# Altium schematic sheet ee453_pcb_N-B-S.SchDoc(ee453_pcb_N-B-S)
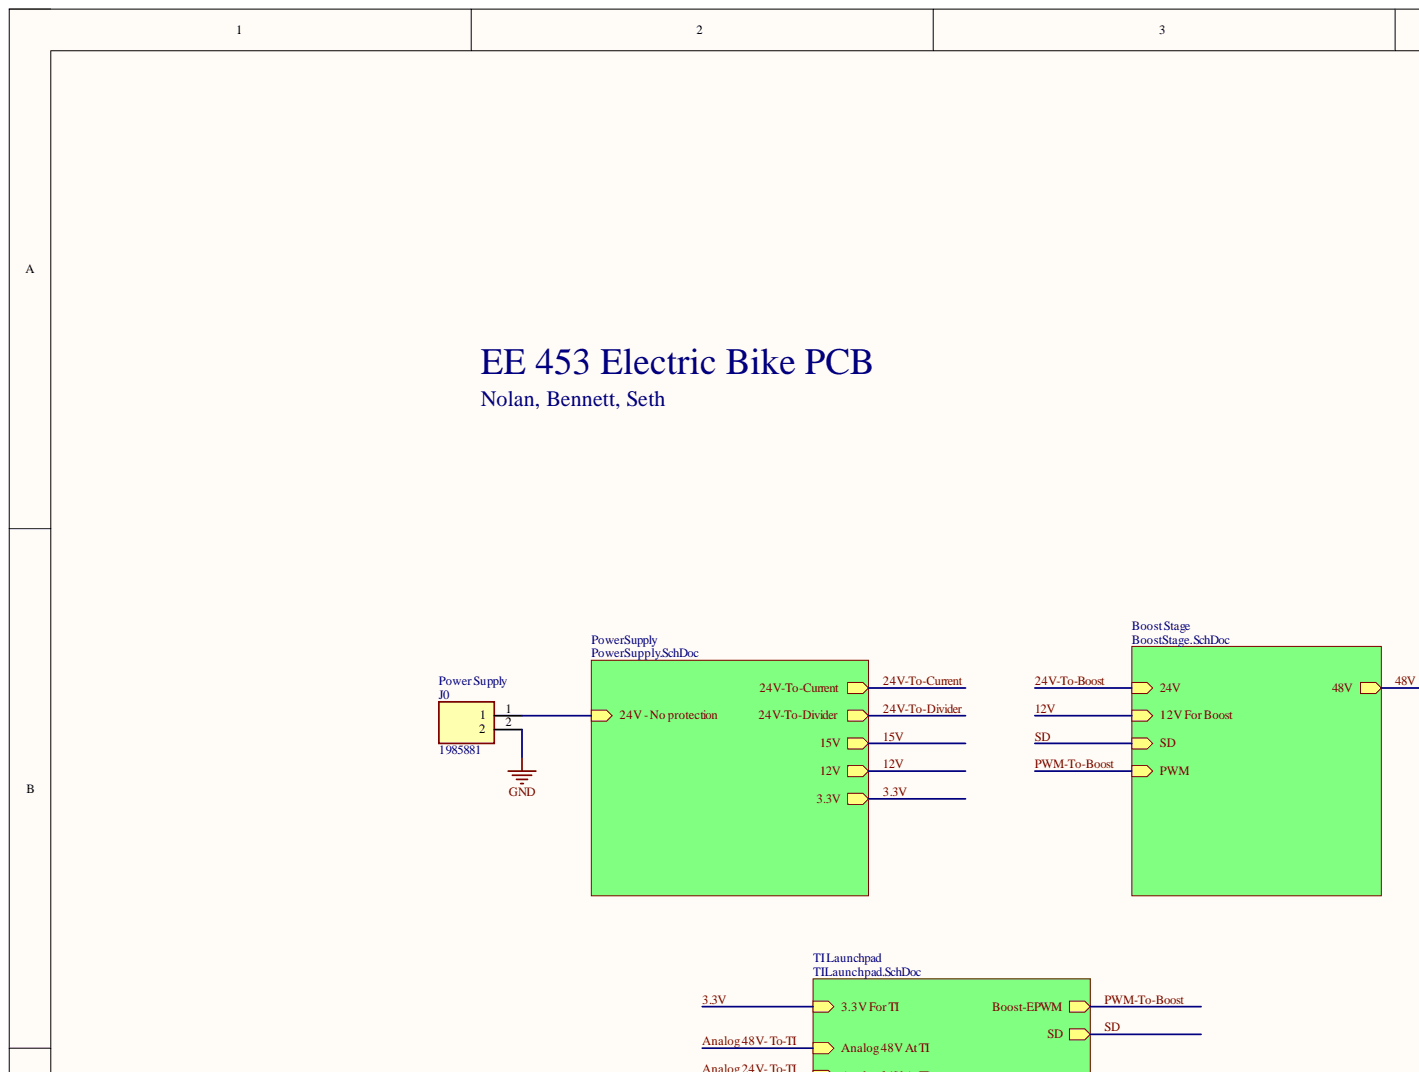
[diagram p0: ee453_pcb_N-B-S.SchDoc, top left]
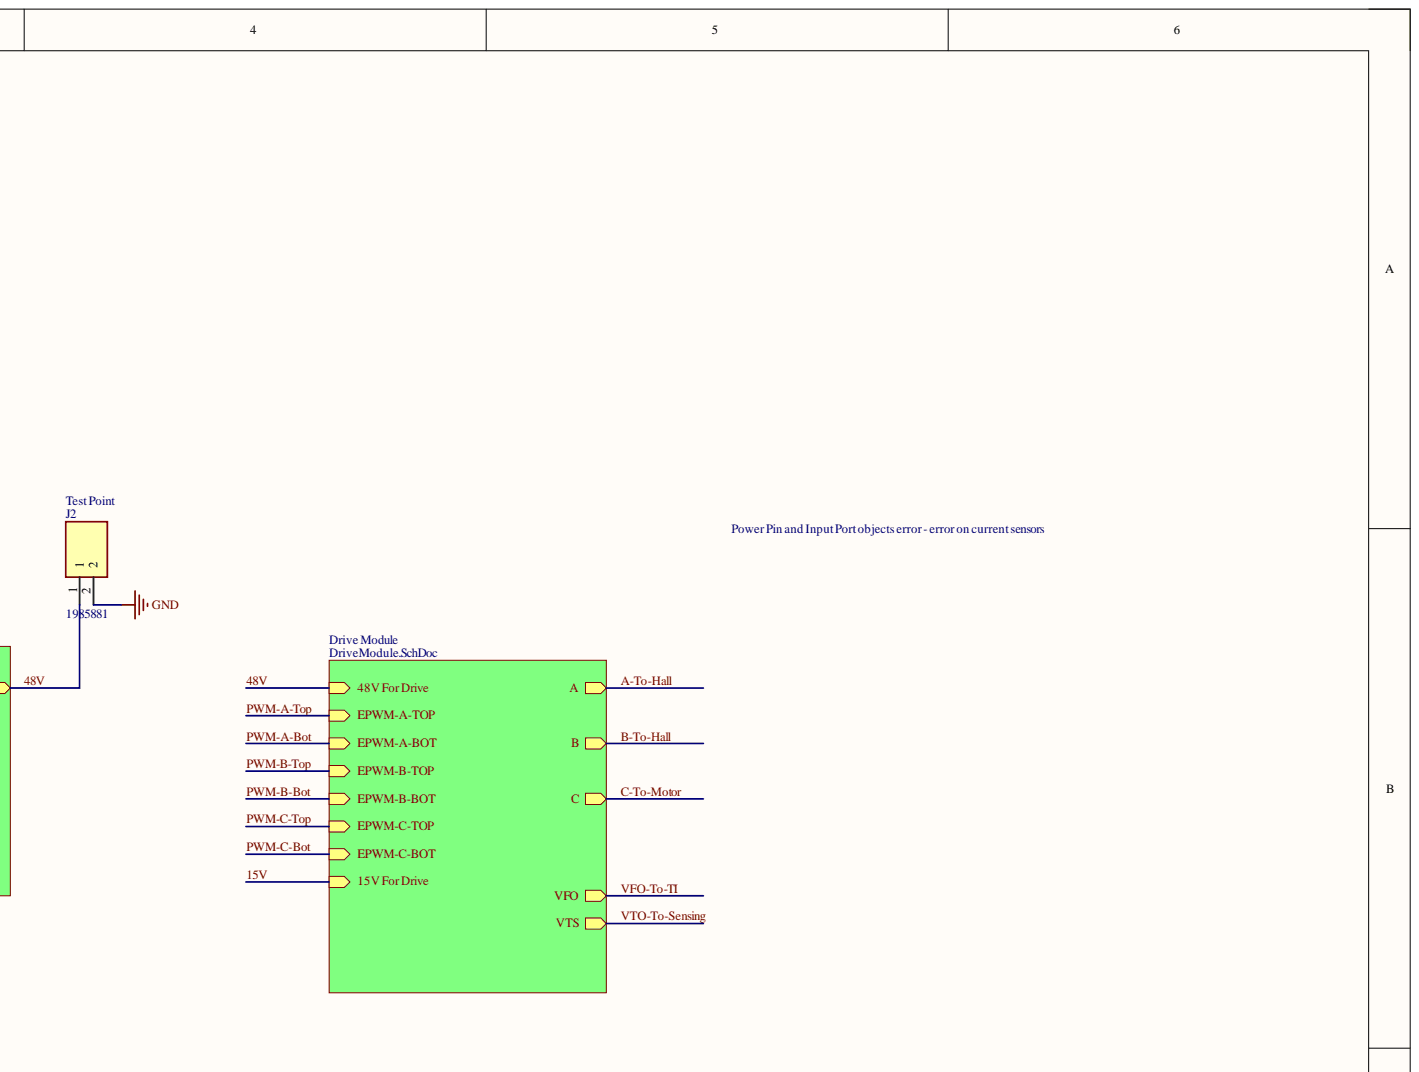
[diagram p1: ee453_pcb_N-B-S.SchDoc, top right]
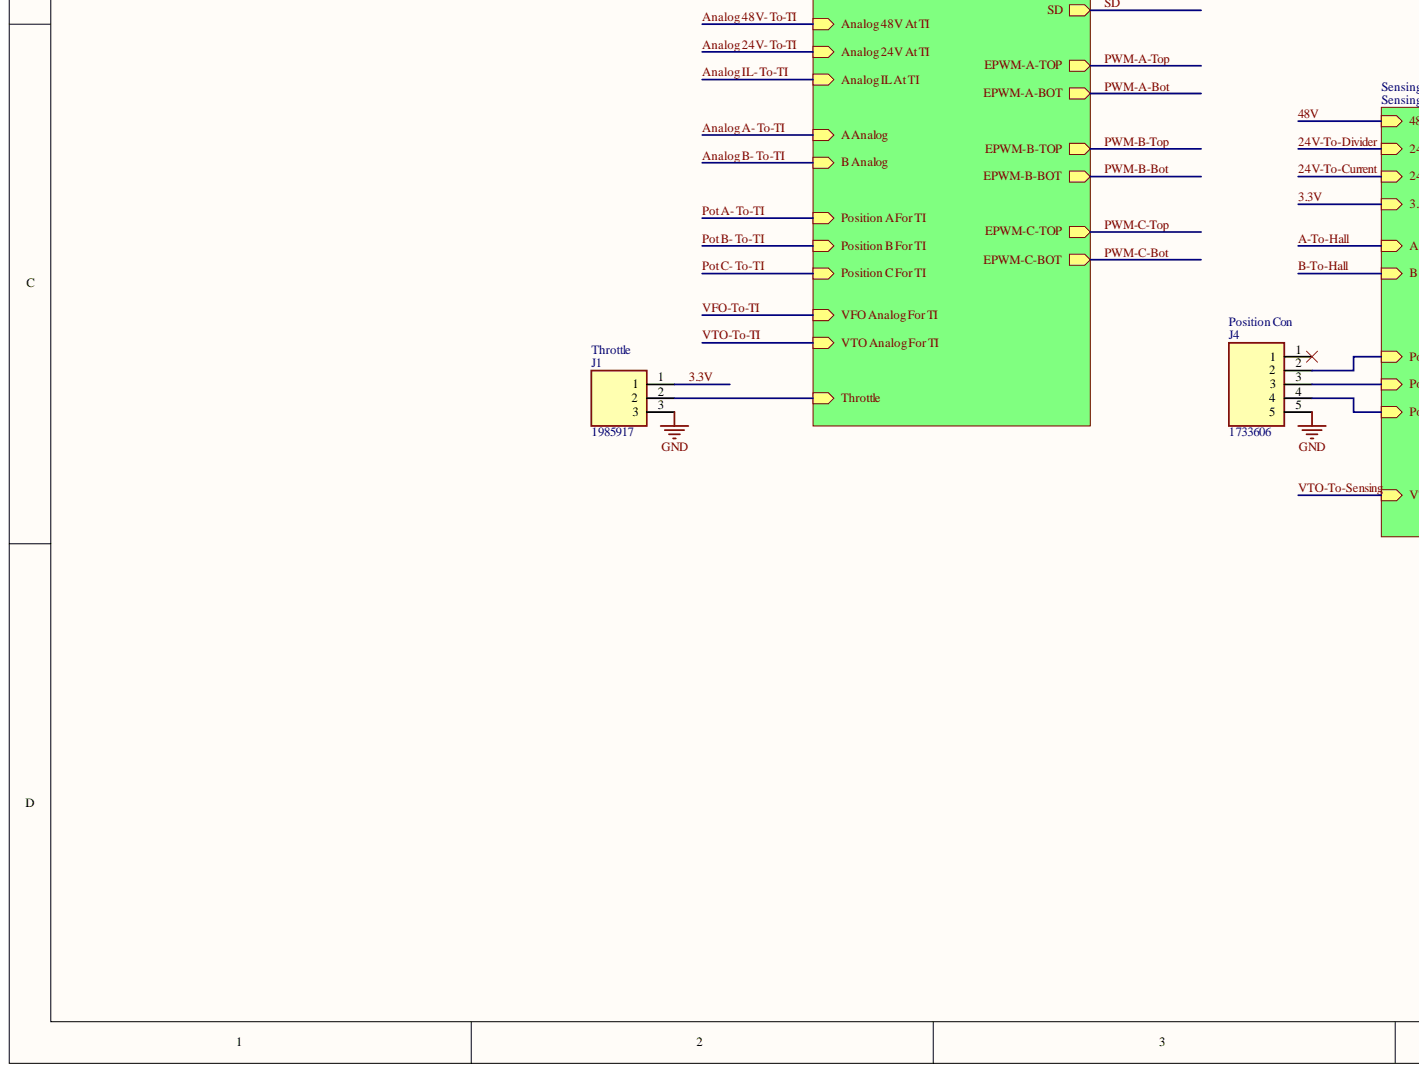
[diagram p2: ee453_pcb_N-B-S.SchDoc, bottom left]
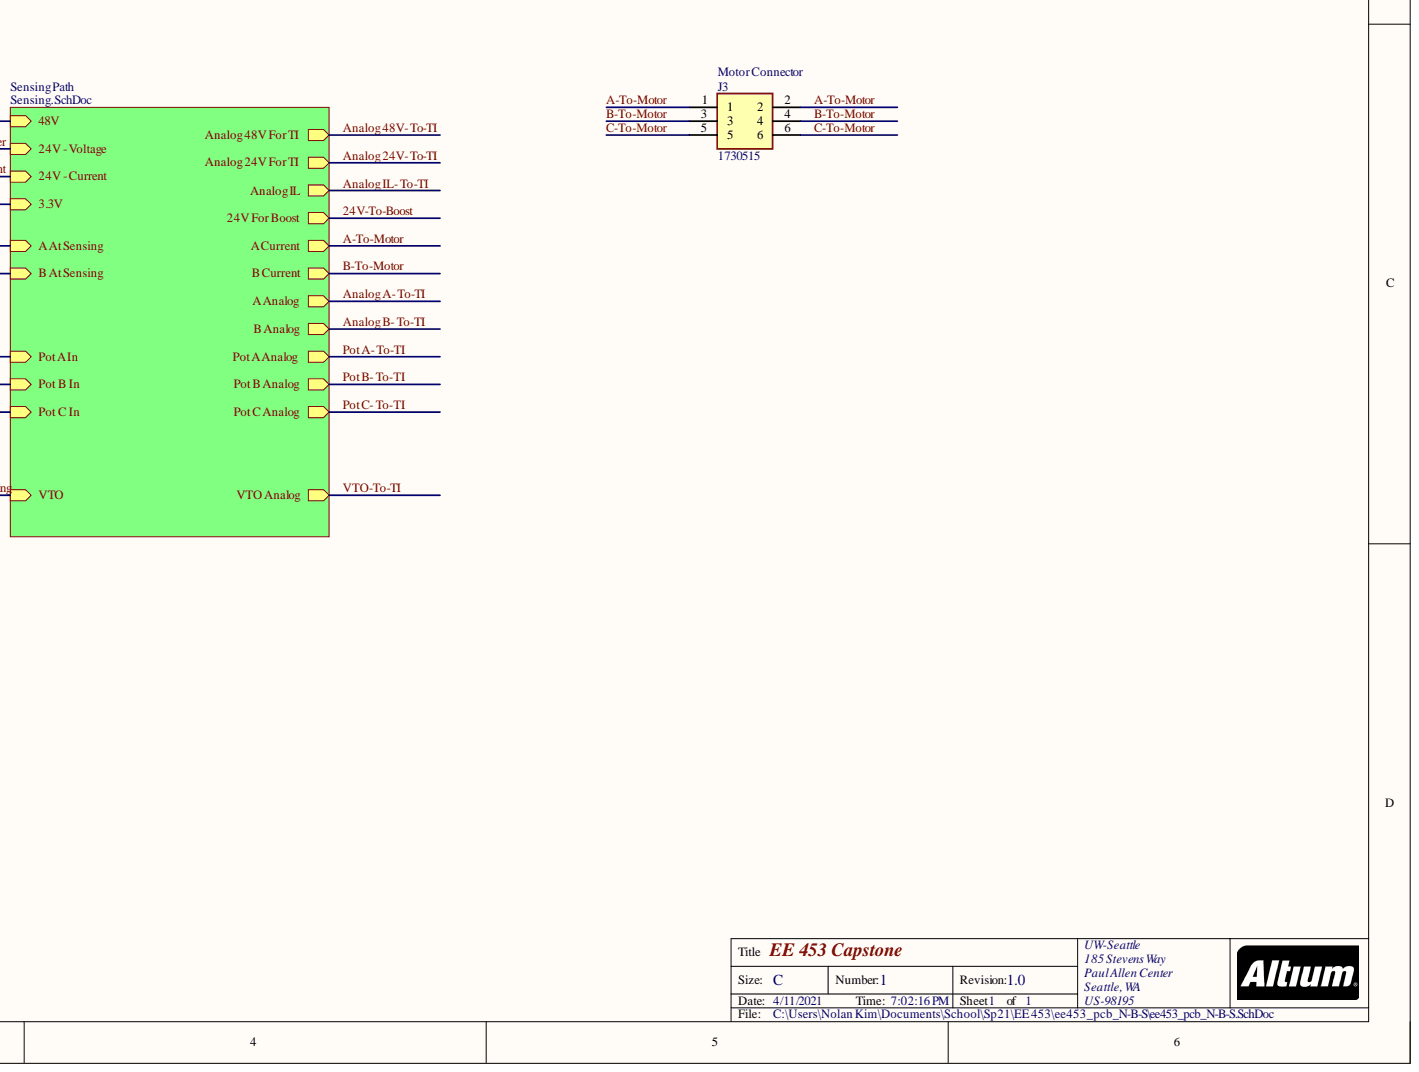
[diagram p3: ee453_pcb_N-B-S.SchDoc, bottom right]

## Parts
J0  1985881  [1985881]
J1  1985917  [1985917]
J2  1985881  [1985881]
J3  1730515  [1730515]
J4  1733606  [1733606]

## Nets
GND: J0.2 J1.3 J2.2 J4.5
3.3V: J1.1
48V: J2.1
A-To-Motor: J3.1 J3.2
B-To-Motor: J3.3 J3.4
C-To-Motor: J3.5 J3.6
NetJ0_1: J0.1
NetJ1_2: J1.2
NetJ4_1: J4.1
NetJ4_2: J4.2
NetJ4_3: J4.3
NetJ4_4: J4.4
12V:
15V:
24V-To-Boost:
24V-To-Current:
24V-To-Divider:
A-To-Hall:
Analog 24V- To-TI:
Analog 48V- To-TI:
Analog A- To-TI:
Analog B- To-TI:
Analog IL- To-TI:
B-To-Hall:
Pot A- To-TI:
Pot B- To-TI:
Pot C- To-TI:
PWM-A-Bot:
PWM-A-Top:
PWM-B-Bot:
PWM-B-Top:
PWM-C-Bot:
PWM-C-Top:
PWM-To-Boost:
SD:
VFO-To-TI:
VTO-To-Sensing:
VTO-To-TI:

## Library data of the parts
J0 J2 = 1985881: Connector; Manufacturer_Name: Phoenix Contact; Component Kind: Standard; Datasheet Link: https://www.digikey.com/en/products/detail/phoenix-contact/1985881/2511015; Height: 13.9mm; Manufacturer_Part_Number: 1985881
J1 = 1985917: Connector; Manufacturer_Name: Phoenix Contact; Arrow Part Number: 1985917; Arrow Price/Stock: https://www.arrow.com/en/products/1985917/phoenix-contact; Component Kind: Standard; Datasheet Link: https://media.digikey.com/pdf/Data%20Sheets/Phoenix%20Contact%20PDFs/Multi-Postion%20PCTerminal%20Blocks.pdf; Height: 13.95mm; Manufacturer_Part_Number: 1985917; Mouser Part Number: 651-1985917; Mouser Price/Stock: https://www.mouser.co.uk/ProductDetail/Phoenix-Contact/1985917/?qs=ibOxrZ%2Fd8eDdpJplVMXgvQ%3D%3D
J3 = 1730515: Connector; Manufacturer_Name: Phoenix Contact; Arrow Part Number: 1730515; Arrow Price/Stock: https://www.arrow.com/en/products/1730515/phoenix-contact; Component Kind: Standard; Datasheet Link: https://media.digikey.com/PDF/Data%20Sheets/Phoenix%20Contact%20PDFs/1730515.pdf; Height: 18mm; Manufacturer_Part_Number: 1730515; Mouser Part Number: 651-1730515; Mouser Price/Stock: https://www.mouser.com/Search/Refine.aspx?Keyword=651-1730515
J4 = 1733606: Connector; Manufacturer_Name: Phoenix Contact; Arrow Part Number: 1733606; Arrow Price/Stock: https://www.arrow.com/en/products/1733606/phoenix-contact; Component Kind: Standard; Datasheet Link: https://www.phoenixcontact.com/online/portal/us?uri=pxc-oc-itemdetail:pid=1733606&library=usen&tab=1; Height: 15.45mm; Manufacturer_Part_Number: 1733606; Mouser Part Number: 651-1733606; Mouser Price/Stock: https://www.mouser.co.uk/ProductDetail/Phoenix-Contact/1733606/?qs=coeDwHeOZKnxpzvXWWKBZQ%3D%3D
